# Altium schematic sheet cgs81-1.schdoc(cgs81-1)
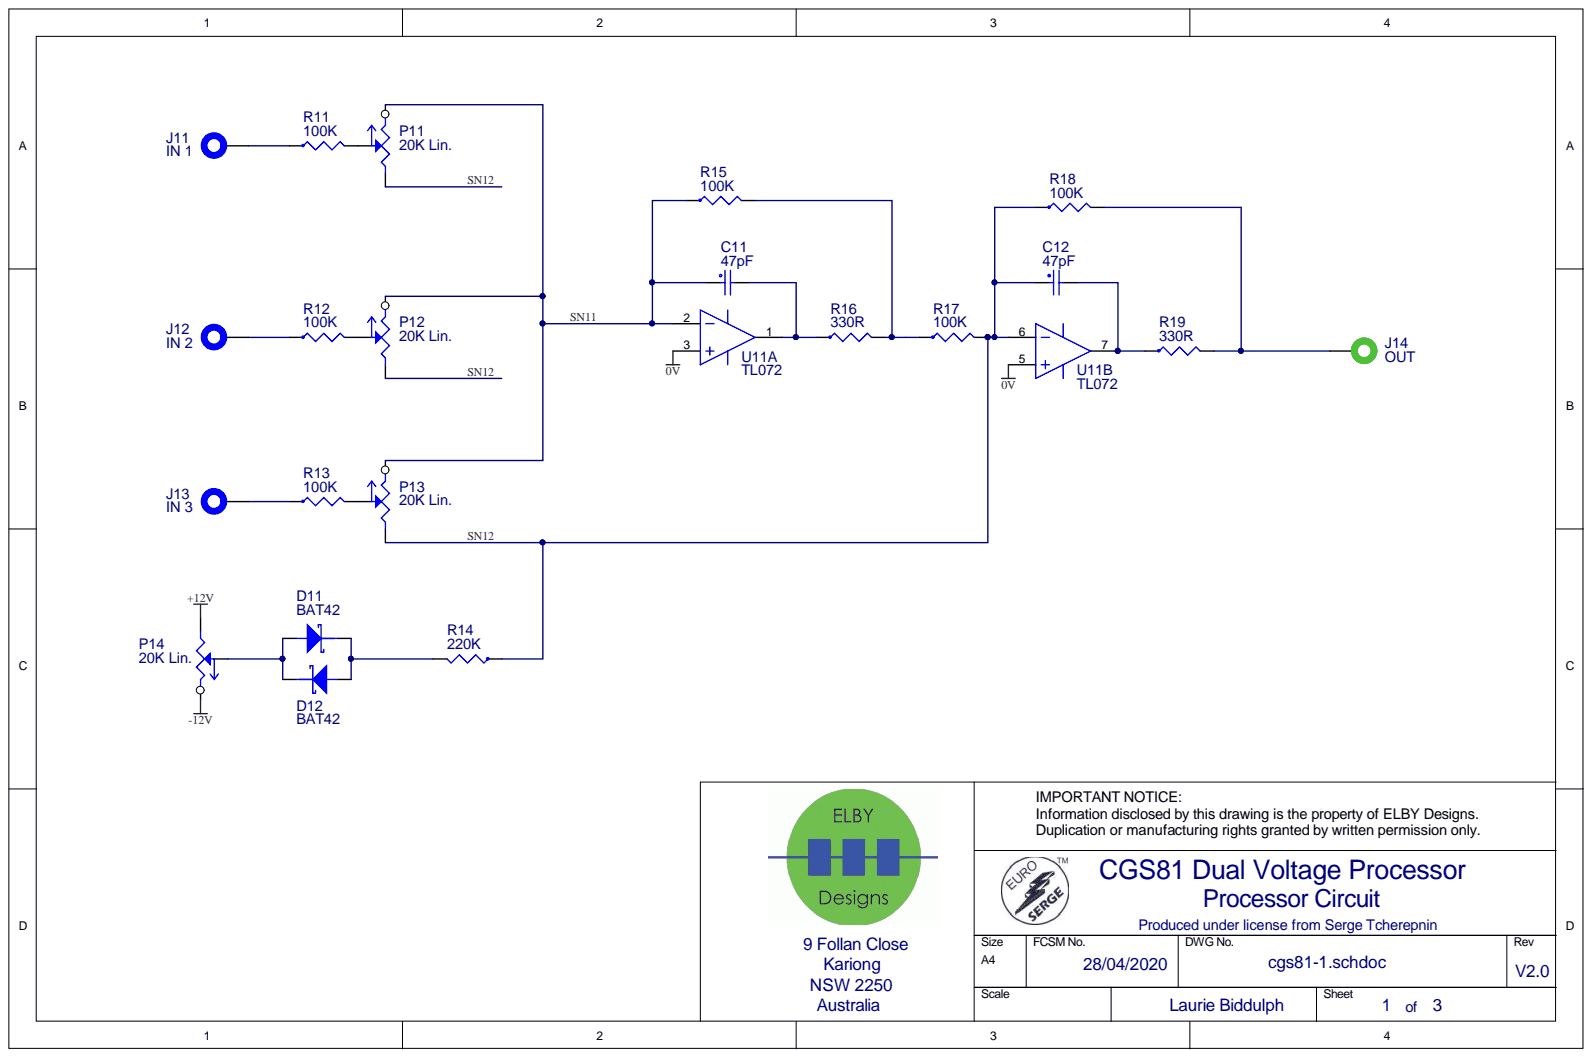
[diagram p0: cgs81-1.schdoc]

## Parts
C11  47pF  [RAD-0.2N(LB)]
C12  47pF  [RAD-0.2N(LB)]
D11  BAT42  [DIODE-0.3(LB)]
D12  BAT42  [DIODE-0.3(LB)]
J11  IN 1  [CGS-WIRE(LB)]
J12  IN 2  [CGS-WIRE(LB)]
J13  IN 3  [CGS-WIRE(LB)]
J14  OUT  [CGS-WIRE(LB)]
P11  20K Lin.  [CGS-POT(LB)]
P12  20K Lin.  [CGS-POT(LB)]
P13  20K Lin.  [CGS-POT(LB)]
P14  20K Lin.  [CGS-POT(LB)]
R11  100K  [AXIAL-0.4(LB)]
R12  100K  [AXIAL-0.4(LB)]
R13  100K  [AXIAL-0.4(LB)]
R14  220K  [AXIAL-0.4(LB)]
R15  100K  [AXIAL-0.4(LB)]
R16  330R  [AXIAL-0.4(LB)]
R17  100K  [AXIAL-0.4(LB)]
R18  100K  [AXIAL-0.4(LB)]
R19  330R  [AXIAL-0.4(LB)]
U11  TL072  [DIP-8(LB)]  (parts A,B)

## Nets
0V: U11.3 U11.5
+12V: P14.3
-12V: P14.1
SN11: C11.1 P11.1 P12.1 P13.1 R15.1 U11.2
SN12: C12.1 P11.3 P12.3 P13.3 R14.1 R17.2 R18.1 U11.6
NetC11_2: C11.2 R16.1 U11.1
NetC12_2: C12.2 R19.1 U11.7
NetD11_A: D11.A D12.K P14.2
NetD11_K: D11.K D12.A R14.2
NetJ11_1: J11.1 R11.1
NetJ12_1: J12.1 R12.1
NetJ13_1: J13.1 R13.1
NetJ14_1: J14.1 R18.2 R19.2
NetP11_2: P11.2 R11.2
NetP12_2: P12.2 R12.2
NetP13_2: P13.2 R13.2
NetR15_2: R15.2 R16.2 R17.1

## Library data of the parts
C11 C12 = CC-47PF(LB): NPO Low K, 50VDC Ceramic Disc; Library Name: capacitor.schlib; EMRP: CC-47PF; Component Kind: Standard
D11 D12 = BAT42(LB): Schottky Diode; EMRP: SD-BAT42; Library Name: semi.schlib; Component Kind: Standard
J11-J13 = BANANA-BLUE: 4mm Banana Socket; Library Name: connectors.schlib; EMRP: ?; Component Kind: Standard
J14 = BANANA-GREEN: 4mm Banana Socket; Library Name: connectors.schlib; EMRP: ?; Component Kind: Standard
P11-P14 = RP-20KLN(LB): Alpha 16S LIN(B); EMRP: RP-1-20KLN; Library Name: potentiometer.schlib; Component Kind: Standard
R11-R13 R15 R17 R18 = RM-100K(LB): 0.5W 1% Metal Film Resistor; Library Name: resistor.schlib; EMRP: RM-100K; Component Kind: Standard
R14 = RM-220K(LB): 0.5W 1% Metal Film Resistor; Library Name: resistor.schlib; EMRP: RM-220K; Component Kind: Standard
R16 R19 = RM-330R(LB): 0.5W 1% Metal Film Resistor; Simulation: 330R; Library Name: resistor.schlib; EMRP: RM-330R; Component Kind: Standard
U11 = TL072(LB): Dual Low-noise JFET Op-amp; EMRP: SI-3-TL072; Library Name: ic.schlib; Component Kind: Standard
